# Altium schematic sheet Headphone Audio Filter.SchDoc("Headphone Audio Filter")
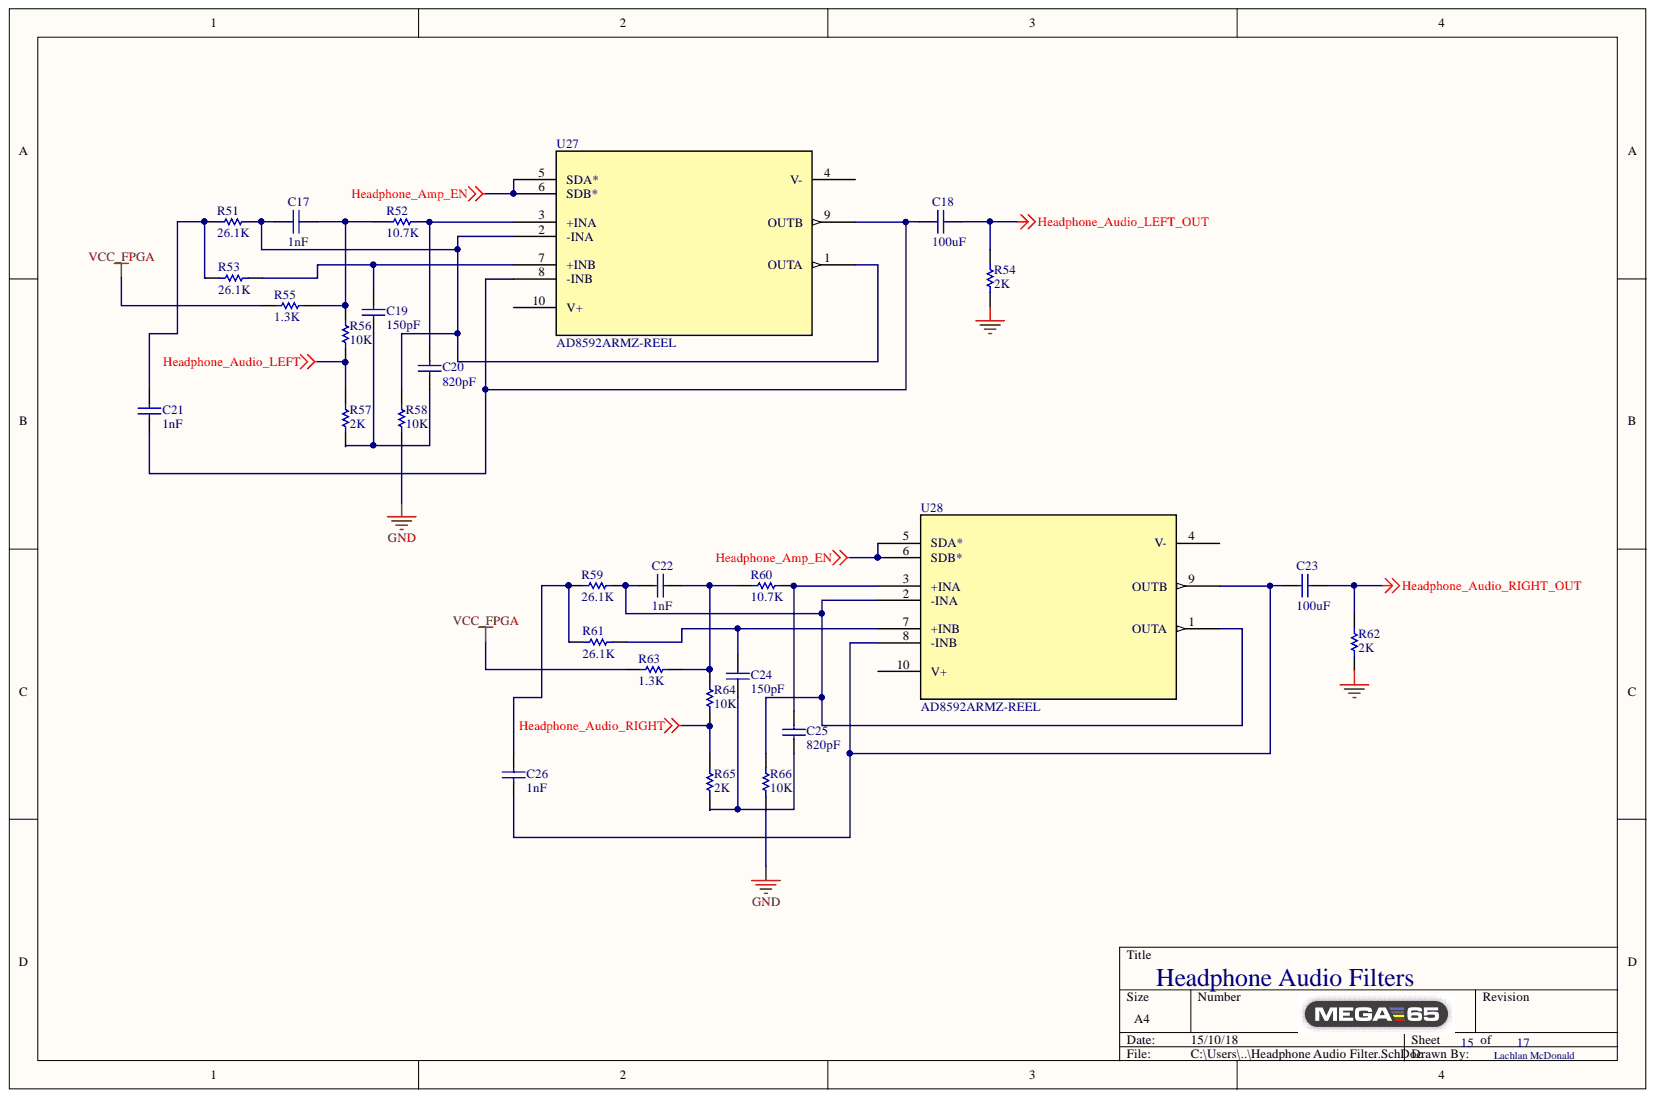
[diagram p0: Headphone Audio Filter.SchDoc]

## Parts
C17  1nF  [CAPC0805(2012)100_N]
C18  100uF  [CAPC0805(2012)100_N]
C19  150pF  [CAPC0805(2012)100_N]
C20  820pF  [CAPC0805(2012)100_N]
C21  1nF  [CAPC0805(2012)100_N]
C22  1nF  [CAPC0805(2012)100_N]
C23  100uF  [CAPC0805(2012)100_N]
C24  150pF  [CAPC0805(2012)100_N]
C25  820pF  [CAPC0805(2012)100_N]
C26  1nF  [CAPC0805(2012)100_N]
R51  26.1K  [RESC0805(2012)_M]
R52  10.7K  [RESC0805(2012)_M]
R53  26.1K  [RESC0805(2012)_M]
R54  2K  [RESC0805(2012)_M]
R55  1.3K  [RESC0805(2012)_M]
R56  10K  [RESC0805(2012)_M]
R57  2K  [RESC0805(2012)_M]
R58  10K  [RESC0805(2012)_M]
R59  26.1K  [RESC0805(2012)_M]
R60  10.7K  [RESC0805(2012)_M]
R61  26.1K  [RESC0805(2012)_M]
R62  2K  [RESC0805(2012)_M]
R63  1.3K  [RESC0805(2012)_M]
R64  10K  [RESC0805(2012)_M]
R65  2K  [RESC0805(2012)_M]
R66  10K  [RESC0805(2012)_M]
U27  AD8592ARMZ-REEL  [RM_10]
U28  AD8592ARMZ-REEL  [RM_10]

## Nets
GND: R54.2 R58.2 R62.2 R66.2
Headphone_Amp_EN: U27.5(SDA*) U27.6(SDB*) U28.5(SDA*) U28.6(SDB*)
Headphone_Audio_LEFT: R56.2 R57.1
Headphone_Audio_LEFT_OUT: C18.1 R54.1
Headphone_Audio_RIGHT: R64.2 R65.1
Headphone_Audio_RIGHT_OUT: C23.1 R62.1
VCC_FPGA: R55.1 R63.1
NetC17_1: C17.1 R52.1 R55.2 R56.1
NetC17_2: C17.2 R51.2 R58.1 U27.1(OUTA) U27.2(-INA)
NetC18_2: C18.2 C21.2 U27.8(-INB) U27.9(OUTB)
NetC19_1: C19.1 C20.1 R57.2
NetC19_2: C19.2 R53.2 U27.7(+INB)
NetC20_2: C20.2 R52.2 U27.3(+INA)
NetC21_1: C21.1 R51.1 R53.1
NetC22_1: C22.1 R60.1 R63.2 R64.1
NetC22_2: C22.2 R59.2 R66.1 U28.1(OUTA) U28.2(-INA)
NetC23_2: C23.2 C26.2 U28.8(-INB) U28.9(OUTB)
NetC24_1: C24.1 C25.1 R65.2
NetC24_2: C24.2 R61.2 U28.7(+INB)
NetC25_2: C25.2 R60.2 U28.3(+INA)
NetC26_1: C26.1 R59.1 R61.1

Not connected: U27 4(V-) 10(V+); U28 4(V-) 10(V+)

## Library data of the parts
C17-C26 = CMP-1036-01531-1: CAP 39pF 100V ±2% 0805 (2012 Metric) Thickness 1mm SMD; Tolerance: Â±2%; Case-Metric: 2012; Case-EIA: 0805; Value: 39pF; Technology: SMT; Rated Voltage: 100 V; Max Thickness: 1 mm; Library Name: Altium Content Vault; Component Kind: Standard
R51-R66 = CMP-1013-00623-1: 10K 0.125W 1% 0805 (2012 Metric)  SMD; Value: 10000.00 Ohm; Case-Metric: 2012; Case-EIA: 0805; Tolerance: 1 %; Technology: SMT; Power: 0.125 W; Library Name: Altium Content Vault; Component Kind: Standard
U27 U28 = AD8592ARMZ-REEL: Imported; Vendor: Analog Devices Inc; Built_By: EMA_UL_Team; Value: Value; Type: DEV; RefDes: RefDes; Manufacturer_Part_Number: AD8592ARMZ-REEL; Library Name: AltiumDesigner.SchLib; Component Kind: Standard
